# Altium schematic sheet 02.IP101GRI.SchDoc
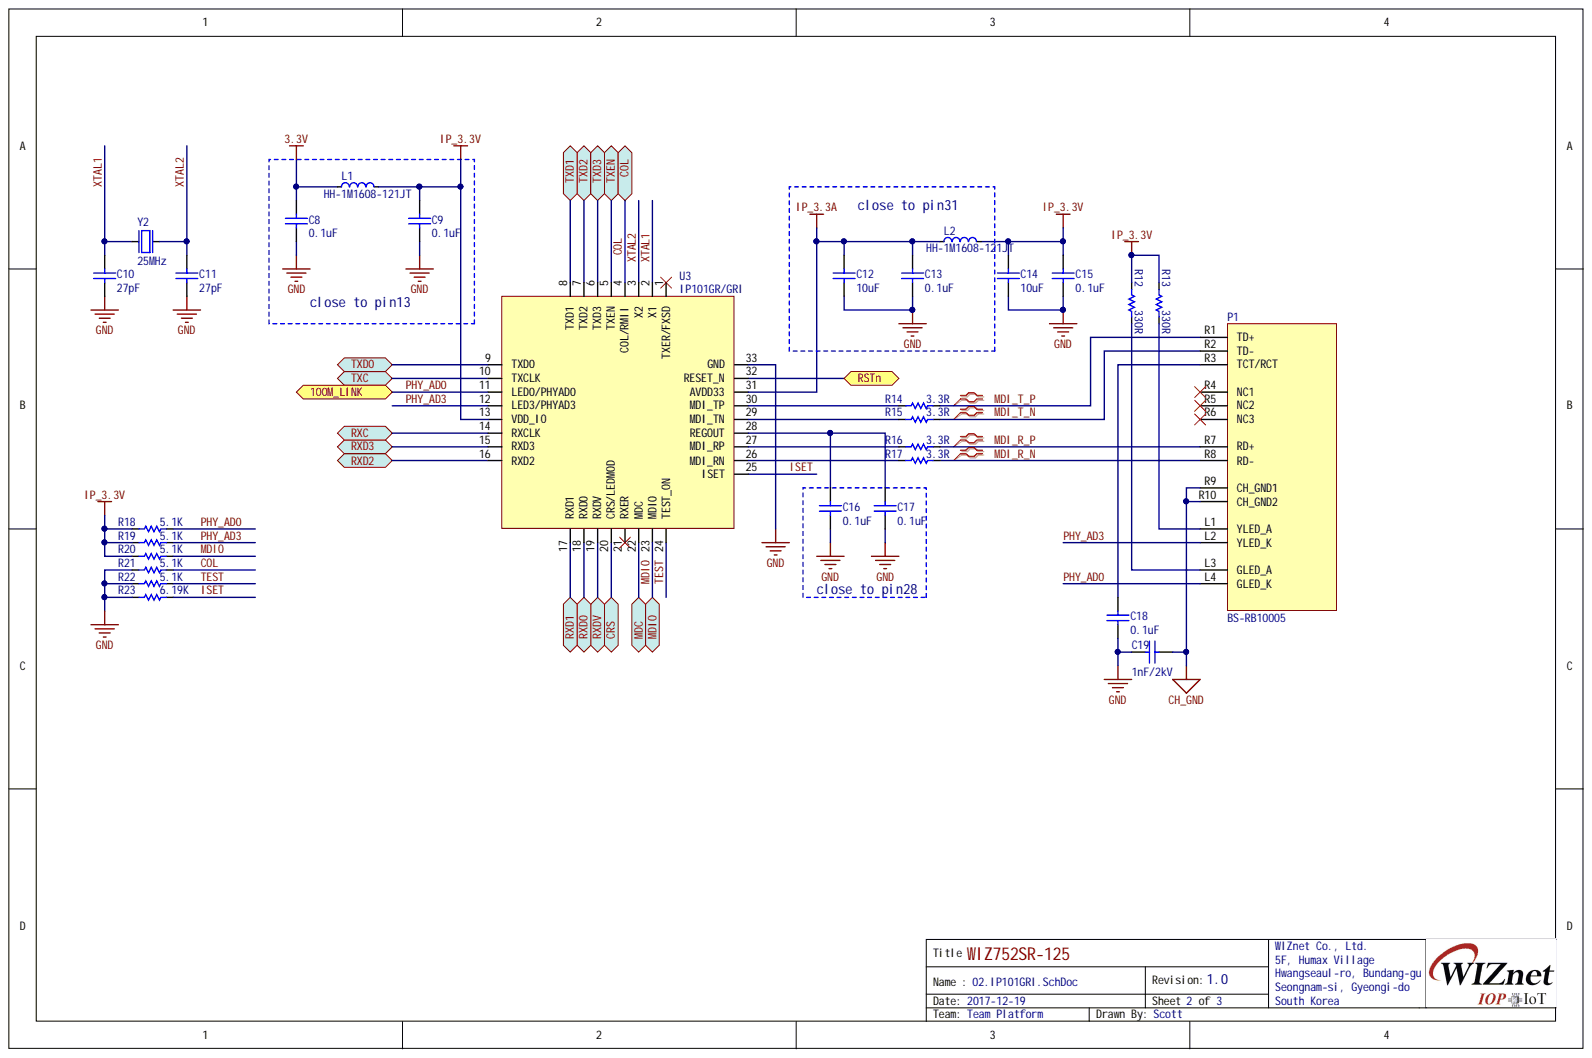
[diagram p0: 02.IP101GRI.SchDoc]

## Parts
C8  0.1uF  [CAP-0603]
C9  0.1uF  [CAP-0603]
C10  27pF  [CAP-0603]
C11  27pF  [CAP-0603]
C12  10uF  [CAP-1206]
C13  0.1uF  [CAP-0603]
C14  10uF  [CAP-1206]
C15  0.1uF  [CAP-0603]
C16  0.1uF  [CAP-0603]
C17  0.1uF  [CAP-0603]
C18  0.1uF  [CAP-0603]
C19  1nF/2kV  [CAP-1808]
L1  HH-1M1608-121JT  [IND-0603]
L2  HH-1M1608-121JT  [IND-0603]
P1  BS-RB10005  [RJ45-RB10005]
R12  330R  [RES-0603]
R13  330R  [RES-0603]
R14  3.3R  [RES-0603]
R15  3.3R  [RES-0603]
R16  3.3R  [RES-0603]
R17  3.3R  [RES-0603]
R18  5.1K  [RES-0603]
R19  5.1K  [RES-0603]
R20  5.1K  [RES-0603]
R21  5.1K  [RES-0603]
R22  5.1K  [RES-0603]
R23  6.19K  [RES-0603]
U3  IP101GR/GRI  [QFN32(0.4/4X4)]
Y2  25MHz  [OSC-82J0626]

## Ports
100M_LINK, COL, CRS, MDC, MDIO, RSTn, RXC, RXD0, RXD1, RXD2, RXD3, RXDV, TXC, TXD0, TXD1, TXD2, TXD3, TXEN

## Nets
3.3V: C8.2 L1.1
CH_GND: C19.2 P1.R9(CH_GND1) P1.R10(CH_GND2)
GND: C8.1 C9.1 C10.1 C11.1 C12.1 C13.1 C14.1 C15.1 C16.1 C17.1 C18.1 C19.1 R21.2 R22.2 R23.2 U3.33(GND) Y2.2 Y2.4
IP_3.3A: C12.2 C13.2 L2.1 U3.31(AVDD33)
IP_3.3V: C9.2 C14.2 C15.2 L1.2 L2.2 R12.1 R13.1 R18.2 R19.2 R20.2 U3.13(VDD_IO)
COL: R21.1 U3.4(COL/RMII) ; port COL
CRS: U3.20(CRS/LEDMOD) ; port CRS
ISET: R23.1 U3.25(ISET)
MDC: U3.22(MDC) ; port MDC
MDI_R_N: P1.R8(RD-) R17.1
MDI_R_P: P1.R7(RD+) R16.1
MDI_T_N: P1.R2(TD-) R15.1
MDI_T_P: P1.R1(TD+) R14.1
MDIO: R20.1 U3.23(MDIO) ; port MDIO
PHY_AD0: P1.L4(GLED_K) R18.1 U3.11(LED0/PHYAD0) ; port 100M_LINK
PHY_AD3: P1.L2(YLED_K) R19.1 U3.12(LED3/PHYAD3)
RSTn: U3.32(RESET_N) ; port RSTn
RXC: U3.14(RXCLK) ; port RXC
RXD0: U3.18(RXD0) ; port RXD0
RXD1: U3.17(RXD1) ; port RXD1
RXD2: U3.16(RXD2) ; port RXD2
RXD3: U3.15(RXD3) ; port RXD3
RXDV: U3.19(RXDV) ; port RXDV
TEST: R22.1 U3.24(TEST_ON)
TXC: U3.10(TXCLK) ; port TXC
TXD0: U3.9(TXD0) ; port TXD0
TXD1: U3.8(TXD1) ; port TXD1
TXD2: U3.7(TXD2) ; port TXD2
TXD3: U3.6(TXD3) ; port TXD3
TXEN: U3.5(TXEN) ; port TXEN
XTAL1: C10.2 U3.2(X1) Y2.1
XTAL2: C11.2 U3.3(X2) Y2.3
NetC16_2: C16.2 C17.2 U3.28(REGOUT)
NetC18_2: C18.2 P1.R3(TCT/RCT)
NetP1_L1: P1.L1(YLED_A) R13.2
NetP1_L3: P1.L3(GLED_A) R12.2
NetR14_2: R14.2 U3.30(MDI_TP)
NetR15_2: R15.2 U3.29(MDI_TN)
NetR16_2: R16.2 U3.27(MDI_RP)
NetR17_2: R17.2 U3.26(MDI_RN)

Not connected: P1 R4(NC1) R5(NC2) R6(NC3); U3 1(TXER/FXSD) 21(RXER)

## Library data of the parts
C8-C11 C13 C15-C18 = CAP-0603: Ceramic CAP 0603(1608); Component Kind: Standard; Library Name: Scott_lib.IntLib
C12 C14 = CAP-1206: Ceramic CAP 1206(3216); Component Kind: Standard; Library Name: Scott_lib.IntLib
C19 = CAP-1808: Ceramic CAP 1808(4520); Component Kind: Standard; Library Name: Scott_lib.IntLib
L1 L2 = IND-0603: Inductor 0603(1608); Component Kind: Standard; Library Name: Scott_lib.IntLib
P1 = RB1-125BAG1A: 10/100 BASE-TX MAGJACK; Component Kind: Standard; Library Name: Edward.IntLib
R12-R23 = RES-0603: RES0603; Component Kind: Standard; Library Name: Scott_lib.IntLib
U3 = IP101GR/GRI: Pakage: VQFN-32; Component Kind: Standard; Part_name: IP101GR/GRI; Library Name: Edward.IntLib
Y2 = OSC-82J0626-25MHz: X-tal 25MHz,3.2x2.5 SMD, CL=18pF; Component Kind: Standard; Library Name: Scott_lib.IntLib
